# Altium schematic sheet [16]-ETH_SWITCH.SchDoc([16]-ETH_SWITCH)
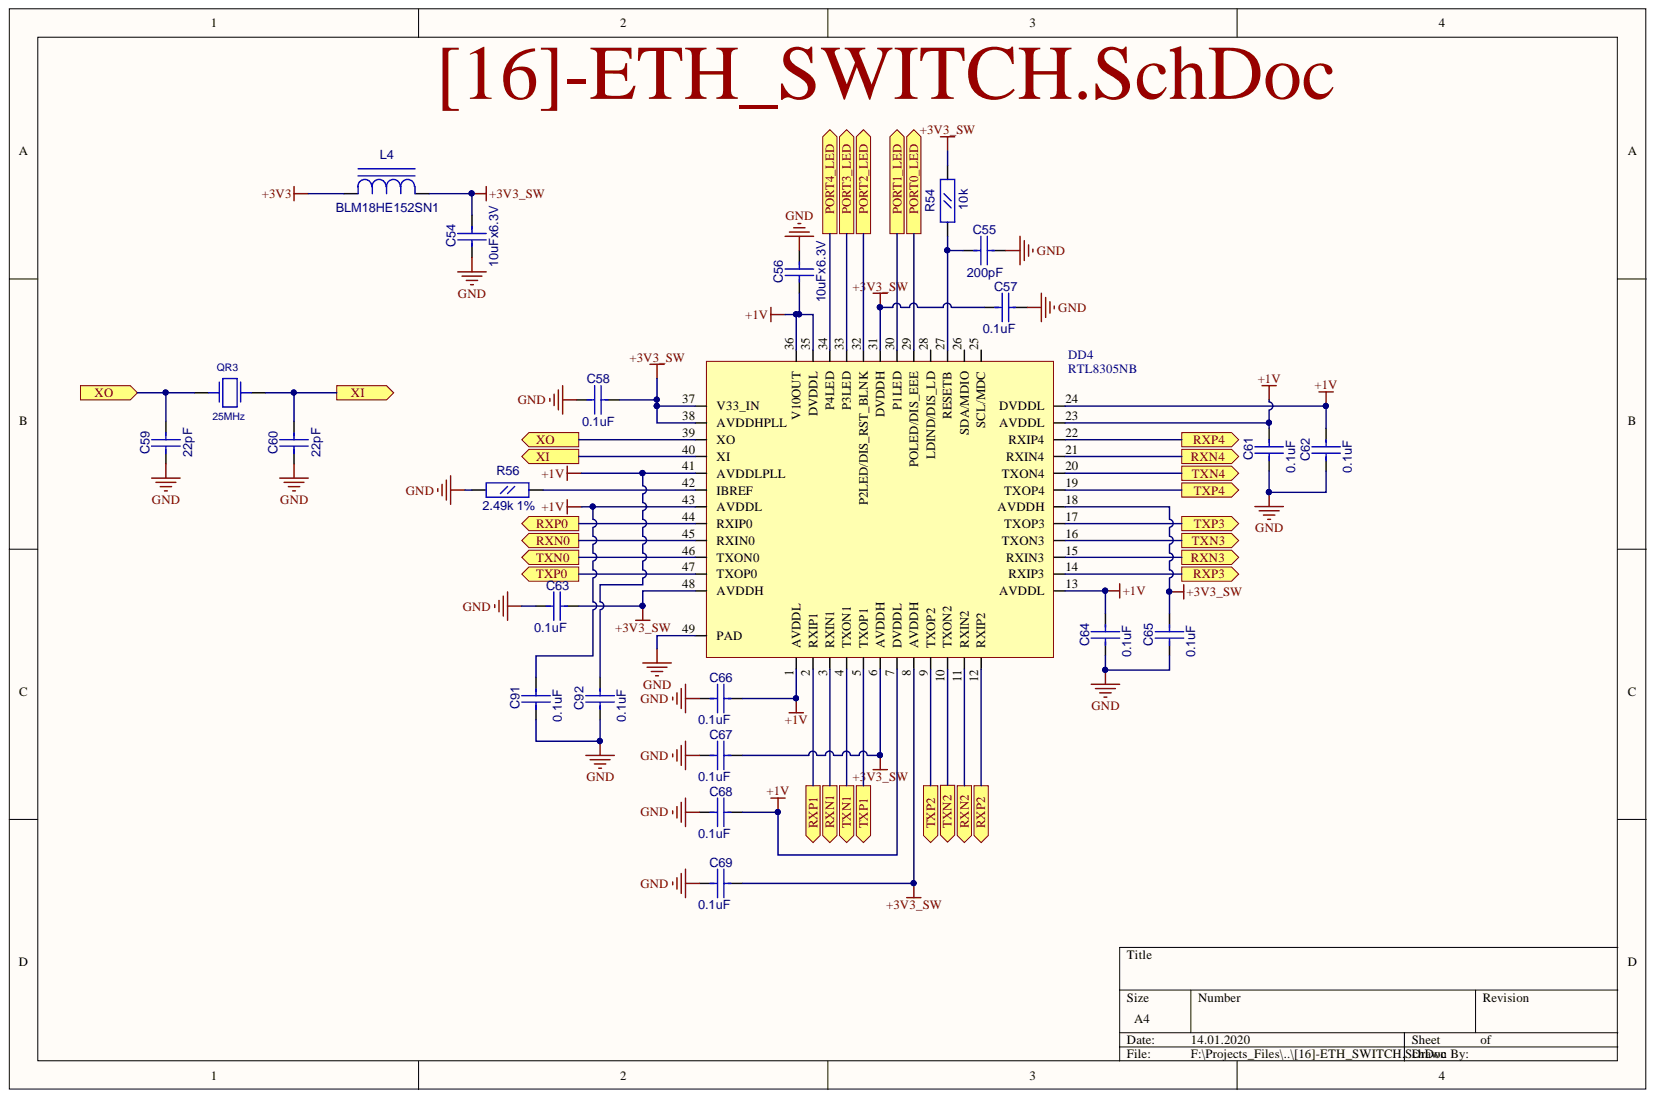
[diagram p0: [16]-ETH_SWITCH.SchDoc]

## Parts
C54  10uFx6.3V  [CC0805]
C55  200pF  [CC0603]
C56  10uFx6.3V  [CC0805]
C57  0.1uF  [CC0603]
C58  0.1uF  [CC0603]
C59  22pF  [CC0603]
C60  22pF  [CC0603]
C61  0.1uF  [CC0603]
C62  0.1uF  [CC0603]
C63  0.1uF  [CC0603]
C64  0.1uF  [CC0603]
C65  0.1uF  [CC0603]
C66  0.1uF  [CC0603]
C67  0.1uF  [CC0603]
C68  0.1uF  [CC0603]
C69  0.1uF  [CC0603]
C91  0.1uF  [CC0603]
C92  0.1uF  [CC0603]
DD4  RTL8305NB  [QFN48_ISL]
L4  BLM18HE152SN1  [L0603]
QR3  25MHz  [AC5032_2]
R54  10k  [RC0603]
R56  2.49k 1%  [RC0603]

## Ports
PORT0_LED, PORT1_LED, PORT2_LED, PORT3_LED, PORT4_LED, RXN0, RXN1, RXN2, RXN3, RXN4, RXP0, RXP1, RXP2, RXP3, RXP4, TXN0, TXN1, TXN2, TXN3, TXN4, TXP0, TXP1, TXP2, TXP3, TXP4, XI, XO

## Nets
+1V: C56.1 C61.2 C62.2 C64.2 C66.2 C68.2 C91.2 C92.2 DD4.1(AVDDL) DD4.7(DVDDL) DD4.13(AVDDL) DD4.23(AVDDL) DD4.24(DVDDL) DD4.35(DVDDL) DD4.36(V10OUT) DD4.41(AVDDLPLL) DD4.43(AVDDL)
+3V3: L4.1
+3V3_SW: C54.2 C57.1 C58.2 C63.2 C65.2 C67.2 C69.2 DD4.6(AVDDH) DD4.8(AVDDH) DD4.18(AVDDH) DD4.31(DVDDH) DD4.37(V33_IN) DD4.38(AVDDHPLL) DD4.48(AVDDH) L4.2 R54.2
GND: C54.1 C55.2 C56.2 C57.2 C58.1 C59.1 C60.1 C61.1 C62.1 C63.1 C64.1 C65.1 C66.1 C67.1 C68.1 C69.1 C91.1 C92.1 DD4.49(PAD) R56.1
PORT0_LED: DD4.29(POLED/DIS_EEE) ; port PORT0_LED
PORT1_LED: DD4.30(P1LED) ; port PORT1_LED
PORT2_LED: DD4.32(P2LED/DIS_RST_BLNK) ; port PORT2_LED
PORT3_LED: DD4.33(P3LED) ; port PORT3_LED
PORT4_LED: DD4.34(P4LED) ; port PORT4_LED
RXN0: DD4.45(RXIN0) ; port RXN0
RXN1: DD4.3(RXIN1) ; port RXN1
RXN2: DD4.11(RXIN2) ; port RXN2
RXN3: DD4.15(RXIN3) ; port RXN3
RXN4: DD4.21(RXIN4) ; port RXN4
RXP0: DD4.44(RXIP0) ; port RXP0
RXP1: DD4.2(RXIP1) ; port RXP1
RXP2: DD4.12(RXIP2) ; port RXP2
RXP3: DD4.14(RXIP3) ; port RXP3
RXP4: DD4.22(RXIP4) ; port RXP4
TXN0: DD4.46(TXON0) ; port TXN0
TXN1: DD4.4(TXON1) ; port TXN1
TXN2: DD4.10(TXON2) ; port TXN2
TXN3: DD4.16(TXON3) ; port TXN3
TXN4: DD4.20(TXON4) ; port TXN4
TXP0: DD4.47(TXOP0) ; port TXP0
TXP1: DD4.5(TXOP1) ; port TXP1
TXP2: DD4.9(TXOP2) ; port TXP2
TXP3: DD4.17(TXOP3) ; port TXP3
TXP4: DD4.19(TXOP4) ; port TXP4
XI: C60.2 DD4.40(XI) QR3.2 ; port XI
XO: C59.2 DD4.39(XO) QR3.1 ; port XO
NetC55_1: C55.1 DD4.27(RESETB) R54.1
NetDD4_42: DD4.42(IBREF) R56.2

Not connected: DD4 25(SCL/MDC) 26(SDA/MDIO) 28(LDIND/DIS_LD)

## Library data of the parts
C54 C56 = CC0805: Value: 10uFx6.3V; Description: CC0805; Component Kind: Standard; Library Name: fizik_lib.SchLib
C55 C57-C69 C91 C92 = CC0603: Description: CC0603; Component Kind: Standard; Library Name: fizik_lib.SchLib
  C55: Value: 200pF
  C57 C58 C61-C69 C91 C92: Value: 0.1uF
  C59 C60: Value: 22pF
L4 = L0603: Value: BLM18HE152SN1; Description: L0603; Component Kind: Standard; Library Name: gprs_modem_2.SchLib
QR3 = AC5032_2: AC5032/2; Value: 25MHz; Component Kind: Standard; Library Name: fizik_lib.SchLib
R54 R56 = RC0603: Description: RC0603; Component Kind: Standard; Library Name: fizik_lib.SchLib
  R54: Value: 10k
  R56: Value: 2.49k 1%
DD4 = RTL8305NB: Value: RTL8305NB; Component Kind: Standard; Library Name: fizik_lib.SchLib
